# Altium schematic sheet Core.SchDoc
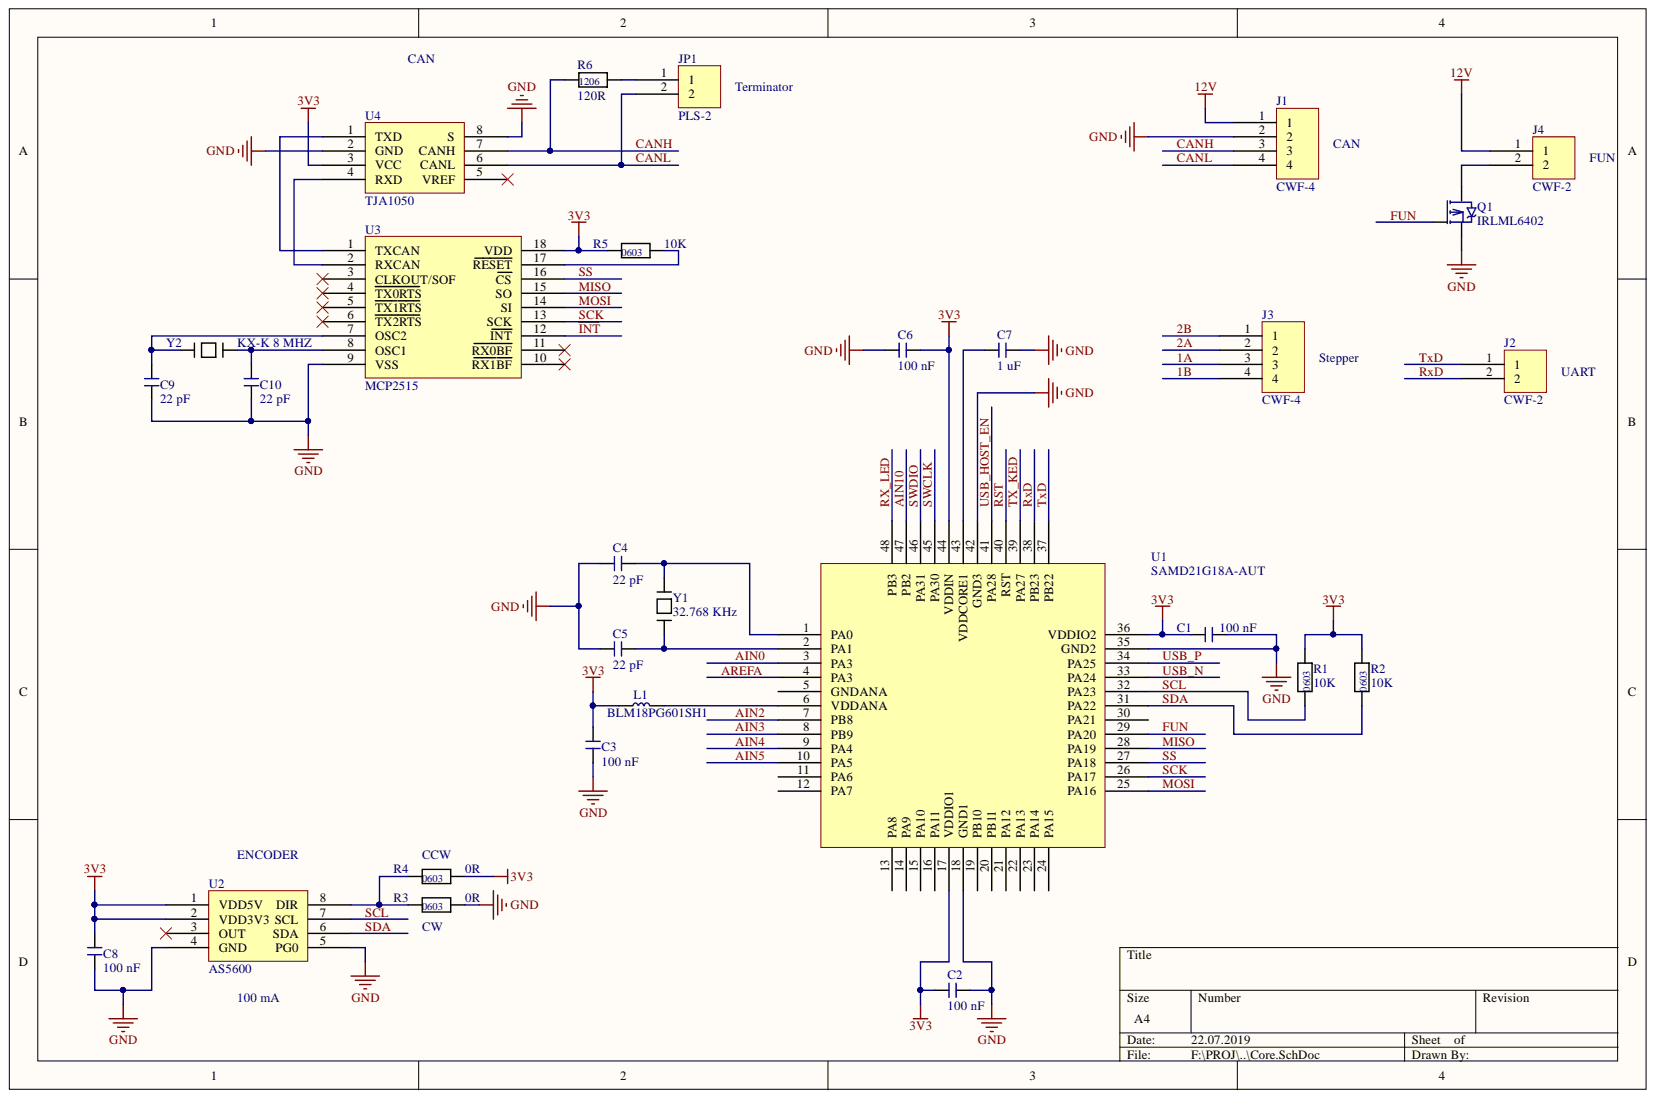
[diagram p0: Core.SchDoc]

## Parts
C1  100 nF  [CHIP_C_0603]
C2  100 nF  [CHIP_C_0603]
C3  100 nF  [CHIP_C_0603]
C4  22 pF  [CHIP_C_0603]
C5  22 pF  [CHIP_C_0603]
C6  100 nF  [CHIP_C_0603]
C7  1 uF  [CHIP_C_0603]
C8  100 nF  [CHIP_C_0603]
C9  22 pF  [CHIP_C_0603]
C10  22 pF  [CHIP_C_0603]
J1  CWF-4  [CWF-4]
J2  CWF-2  [CWF-2]
J3  CWF-4  [CWF-4]
J4  CWF-2  [CWF-2]
JP1  PLS-2  [PLS-2]
L1  BLM18PG601SH1  [CHIP_R_0603]
Q1  IRLML6402  [SOT23]
R1  10K  [CHIP_R_0603]
R2  10K  [CHIP_R_0603]
R3  0R  [CHIP_R_0603]
R4  0R  [CHIP_R_0603]
R5  10K  [CHIP_R_0603]
R6  120R  [CHIP_R_1206]
U1  SAMD21G18A-AUT  [LQFP48]
U2  AS5600  [SOIC8]
U3  MCP2515  [SOIC18]
U4  TJA1050  [SOIC8]
Y1  32.768 KHz  [GSX-1A]
Y2  KX-K 8 MHZ  [KX-K]

## Nets
3V3: C1.1 C2.1 C3.2 C6.2 C8.2 L1.1 R1.2 R2.2 R4.2 R5.1 U1.17(VDDIO1) U1.36(VDDIO2) U1.44(VDDIN) U2.1(VDD5V) U2.2(VDD3V3) U3.18(VDD) U4.3(VCC)
12V: J1.1 J4.1
GND: C1.2 C2.2 C3.1 C4.1 C5.1 C6.1 C7.2 C8.1 C9.1 C10.1 J1.2 Q1.2 R3.2 U1.18(GND1) U1.35(GND2) U1.42(GND3) U2.4(GND) U2.5(PG0) U3.9(VSS) U4.2(GND) U4.8(S)
1A: J3.3
1B: J3.4
2A: J3.2
2B: J3.1
AIN0: U1.3(PA3)
AIN2: U1.7(PB8)
AIN3: U1.8(PB9)
AIN4: U1.9(PA4)
AIN5: U1.10(PA5)
AIN10: U1.47(PB2)
AREFA: U1.4(PA3)
CANH: J1.3 R6.1 U4.7(CANH)
CANL: J1.4 JP1.2 U4.6(CANL)
FUN: Q1.1 U1.29(PA20)
~{INT}: U3.12(INT)
MISO: U1.28(PA19) U3.15(SO)
MOSI: U1.25(PA16) U3.14(SI)
RST: U1.40(RST)
RX_LED: U1.48(PB3)
RXD: J2.2 U1.38(PB23)
SCK: U1.26(PA17) U3.13(SCK)
SCL: R1.1 U1.32(PA23) U2.7(SCL)
SDA: R2.1 U1.31(PA22) U2.6(SDA)
SS: U1.27(PA18) U3.16(CS)
SWCLK: U1.45(PA30)
SWDIO: U1.46(PA31)
TX_KED: U1.39(PA27)
TXD: J2.1 U1.37(PB22)
USB_HOST_EN: U1.41(PA28)
USB_N: U1.33(PA24)
USB_P: U1.34(PA25)
NetC4_2: C4.2 U1.1(PA0) Y1.2
NetC5_2: C5.2 U1.2(PA1) Y1.1
NetC7_1: C7.1 U1.43(VDDCORE1)
NetC9_2: C9.2 U3.7(OSC2) Y2.1
NetC10_2: C10.2 U3.8(OSC1) Y2.2
NetJ4_2: J4.2 Q1.3
NetJP1_1: JP1.1 R6.2
NetL1_2: L1.2 U1.6(VDDANA)
NetR3_1: R3.1 R4.1 U2.8(DIR)
NetR5_2: R5.2 U3.17(RESET)
NetU3_1: U3.1(TXCAN) U4.1(TXD)
NetU3_2: U3.2(RXCAN) U4.4(RXD)

Not connected: U1 5(GNDANA) 11(PA6) 12(PA7) 13(PA8) 14(PA9) 15(PA10) 16(PA11) 19(PB10) 20(PB11) 21(PA12) 22(PA13) 23(PA14) 24(PA15) 30(PA21); U2 3(OUT); U3 3(CLKOUT/SOF) 4(TX0RTS) 5(TX1RTS) 6(TX2RTS) 10(RX1BF) 11(RX0BF); U4 5(VREF)

## Library data of the parts
C1-C10 = CHIP_C: Component Kind: Standard; Library Name: Popular.SchLib
L1 = BLM: Component Kind: Standard; Library Name: Popular.SchLib
Q1 = IRLML6402: p-chanal MOSFET; Component Kind: Standard; Library Name: Popular.SchLib
R1-R5 = RES_0603: Component Kind: Standard; Library Name: Popular.SchLib
R6 = RES_1206: Component Kind: Standard; Library Name: Popular.SchLib
U2 = AS5600: program magnetic rotary position  sensor; Component Kind: Standard; Library Name: Popular.SchLib
Y1 Y2 = Quartz: Component Kind: Standard; Library Name: Popular.SchLib
J1 J3 = CWF-4: Component Kind: Standard; Library Name: Popular.SchLib
J2 J4 = CWF-2: Component Kind: Standard; Library Name: Popular.SchLib
JP1 = PLS-2: Component Kind: Standard; Library Name: Popular.SchLib
U1 = SAMD21G18A-AUT: Component Kind: Standard; Library Name: STM32.SchLib
U3 = MCP2515: Component Kind: Standard; Library Name: Popular.SchLib
U4 = TJA1050: Component Kind: Standard; Library Name: Popular.SchLib
